# Altium schematic sheet LEDs.SchDoc
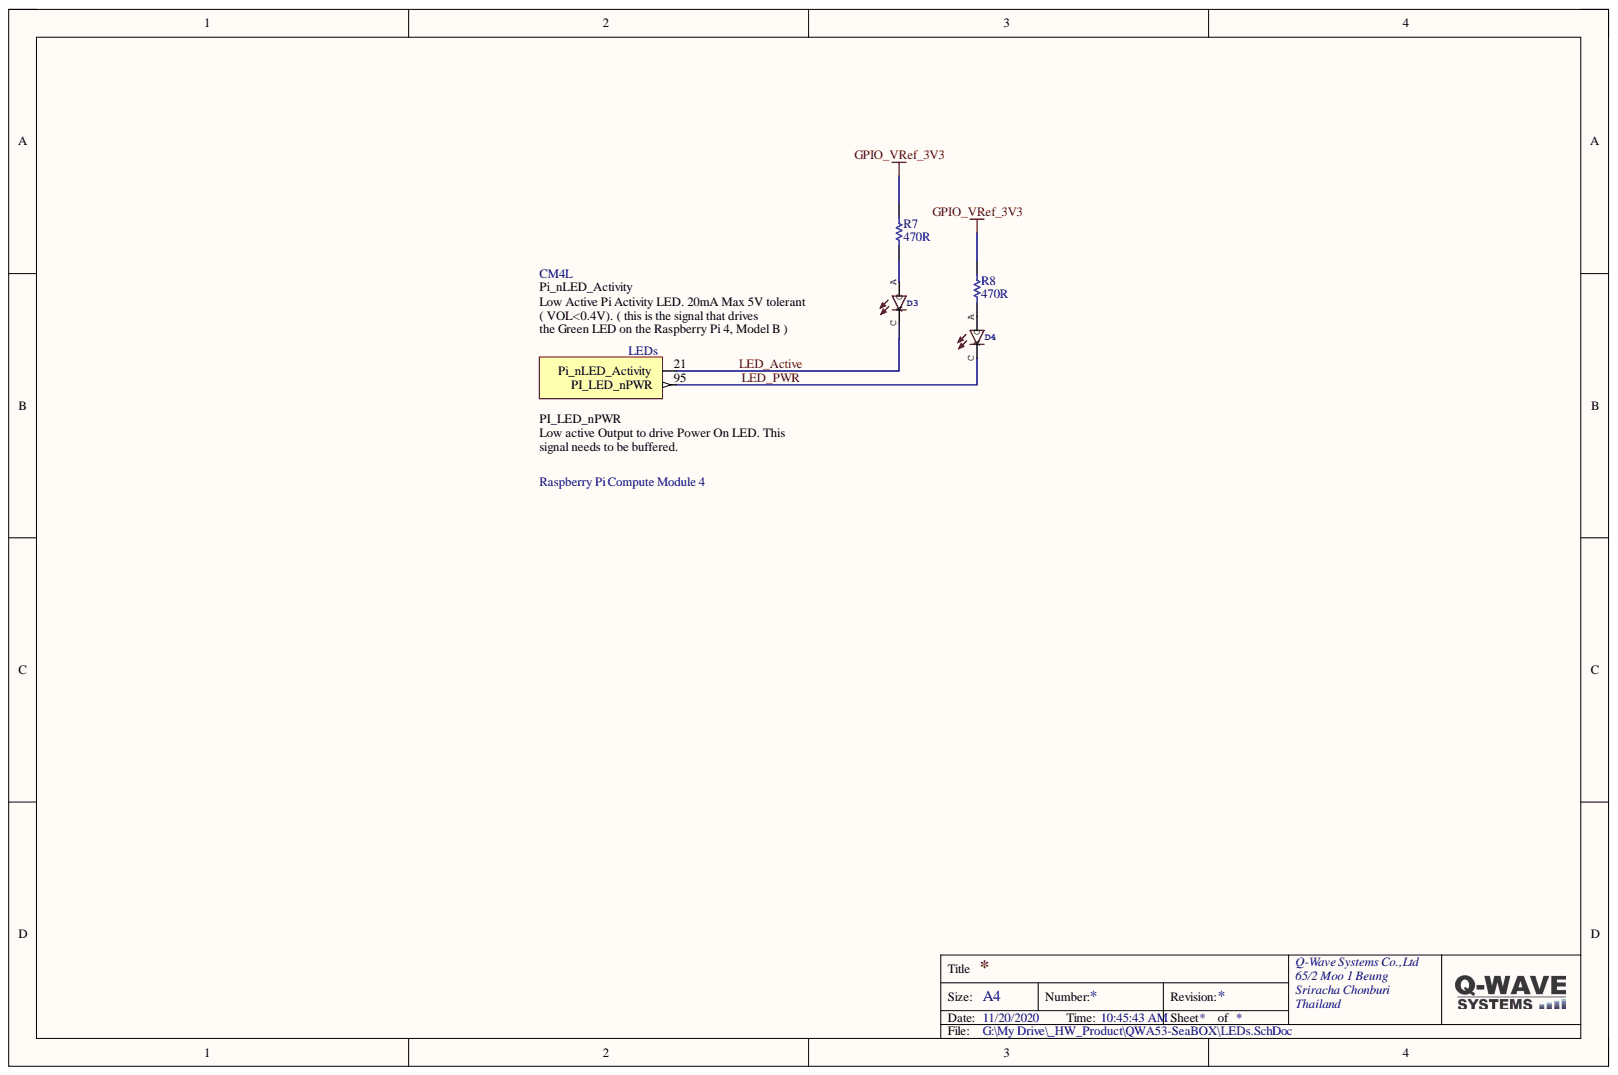
[diagram p0: LEDs.SchDoc]

## Parts
CM4  Raspberry Pi Compute Module 4  [CM4-F40C-100DS-0.4v_1.5mm_0mm]  (part L)
D3  Red SMD LED    Used in manufacturing of most products at SparkFun   Packages: 0603 - SMD 0603 package [Product Link]  0603 SMART - SMD 0603 SMART package ( more efficient and expensive)[Product Link]   1206 - SMD1206  package [Product Link]    SparkFun Products: Pro Mini 328 -5V  RedBoard  IOIO-OTG  [LED-1206-LED_AL-S1204SRC50-14M]  (value not drawn)
D4  Red SMD LED    Used in manufacturing of most products at SparkFun   Packages: 0603 - SMD 0603 package [Product Link]  0603 SMART - SMD 0603 SMART package ( more efficient and expensive)[Product Link]   1206 - SMD1206  package [Product Link]    SparkFun Products: Pro Mini 328 -5V  RedBoard  IOIO-OTG  [LED-1206-LED_AL-S1204SRC50-14M]  (value not drawn)
R7  470R  [RES_0603]
R8  470R  [RES_0603]

## Nets
GPIO_VRef_3V3: R7.2 R8.2
LED_Active: CM4.21 D3.C
LED_PWR: CM4.95 D4.C
NetD3_A: D3.A R7.1
NetD4_A: D4.A R8.1

## Library data of the parts
CM4 = CM4: Component Kind: Standard; Description: Raspberry Pi Compute Module 4; Library Name: CM4.SchLib
D3 D4 = LED-RED1206: LED Red SMD ES P/N 0983-0003-2; DeviceName: 1206; GateName_1: G$1; PROD_ID: DIO-09912; Component Kind: Standard; DeviceSetName: LED-RED; Library Name: SparkFun-LED.IntLib; LibraryName: SparkFun-LED; SymbolName_1: LED
R7 R8 = Res Semi: 470R Resistor 0603; Component Kind: Standard; Library Name: SCH_Passive.SchLib; Signal Integrity: Res Semi; Simulation: RES
